# Altium schematic sheet Power_Supply_Unit.SchDoc
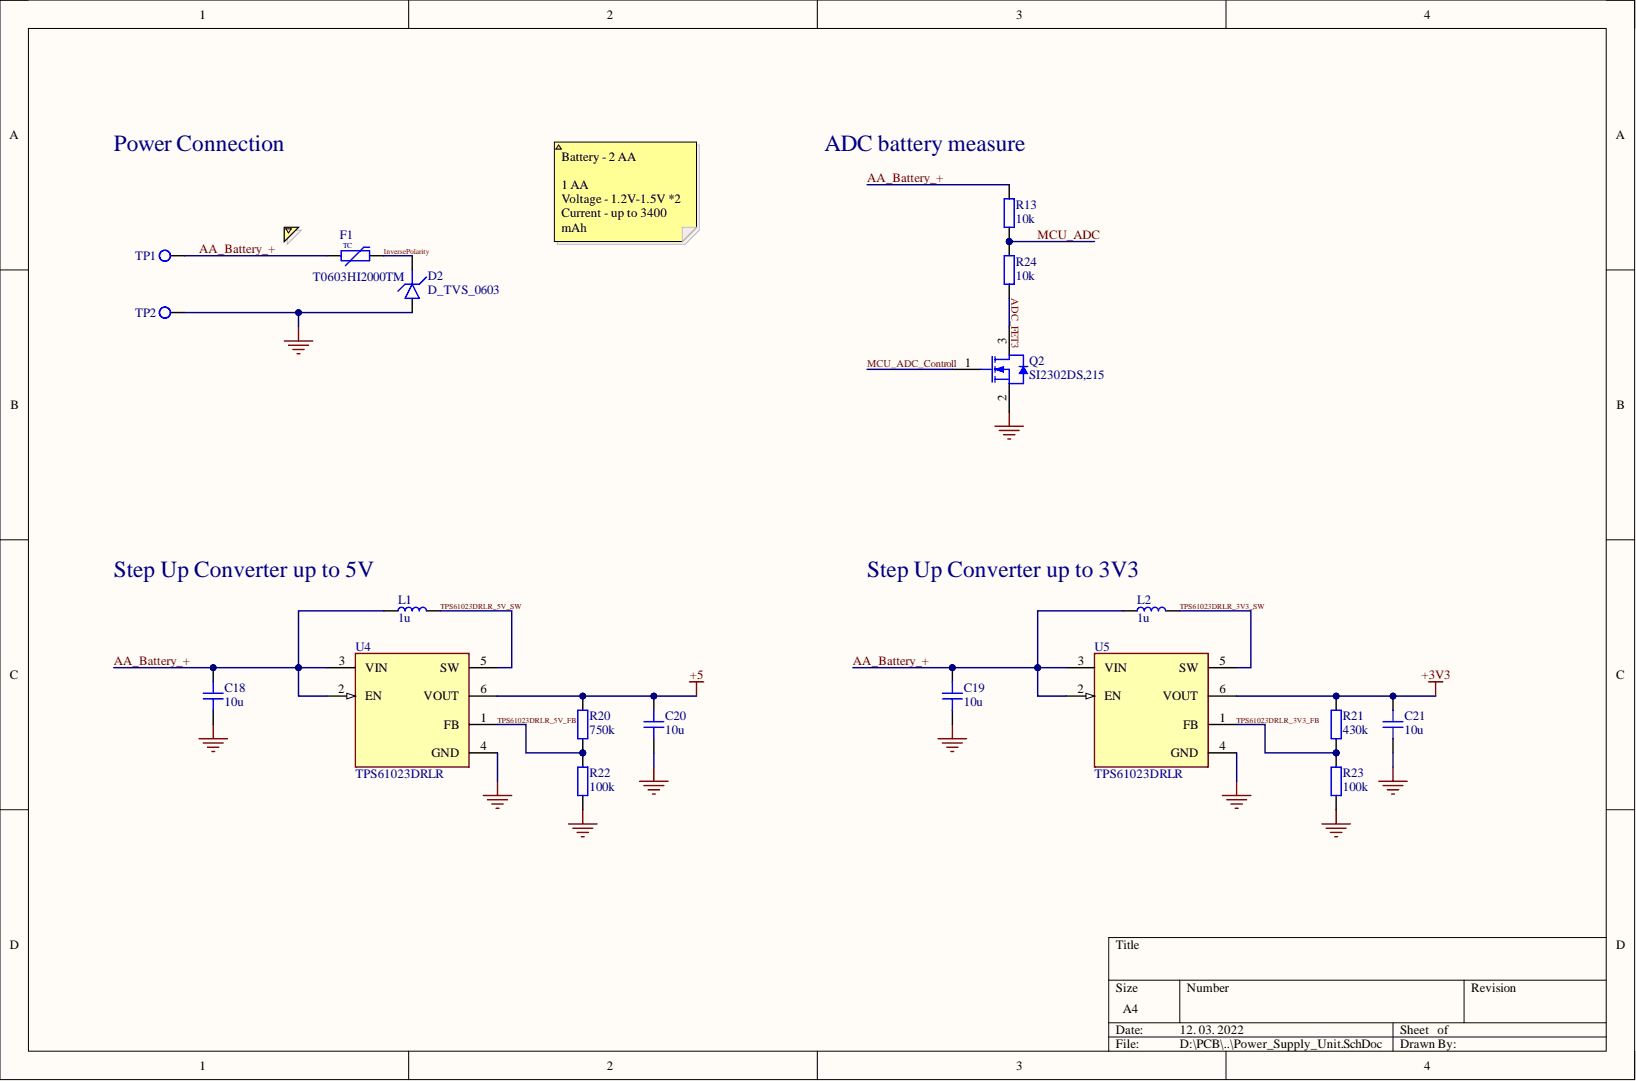
[diagram p0: Power_Supply_Unit.SchDoc]

## Parts
C18  10u  [CAP_0603]
C19  10u  [CAP_0603]
C20  10u  [CAP_0603]
C21  10u  [CAP_0603]
D2  D_TVS_0603  [DIOM2718X14N]
F1  T0603HI2000TM  [RESC1608X06N]
L1  1u  [IND_1210]
L2  1u  [IND_1210]
Q2  SI2302DS,215  [NXP-SOT23_N]
R13  10k  [RES_0603]
R20  750k  [RES_0603]
R21  430k  [RES_0603]
R22  100k  [RES_0603]
R23  100k  [RES_0603]
R24  10k  [RES_0603]
TP1  TestPoint  [SolderingPad]  (value not drawn)
TP2  TestPoint  [SolderingPad]  (value not drawn)
U4  TPS61023DRLR  [FP-DRL0006A-MFG]
U5  TPS61023DRLR  [FP-DRL0006A-MFG]

## Nets
+3V3: C21.2 R21.2 U5.6(VOUT)
+5: C20.2 R20.2 U4.6(VOUT)
GND: C18.1 C19.1 C20.1 C21.1 D2.2 Q2.2 R22.1 R23.1 TP2.1 U4.4(GND) U5.4(GND)
AA_Battery_+: C18.2 C19.2 F1.1 L1.1 L2.1 R13.2 TP1.1 U4.2(EN) U4.3(VIN) U5.2(EN) U5.3(VIN)
ADC_FET3: Q2.3 R24.1
InversePolarity: D2.1 F1.2
MCU_ADC: R13.1 R24.2
MCU_ADC_Controll: Q2.1
TPS61023DRLR_3V3_FB: R21.1 R23.2 U5.1(FB)
TPS61023DRLR_3V3_SW: L2.2 U5.5(SW)
TPS61023DRLR_5V_FB: R20.1 R22.2 U4.1(FB)
TPS61023DRLR_5V_SW: L1.2 U4.5(SW)

## Library data of the parts
C18-C21 = CAP_0603_10V: Capacitor SMD 0603 10V; Component Kind: Standard; Library Name: Schematic-Lib-PhilsLab.SchLib
D2 = D_TVS_0603: Diode TVS / ESD 0603; Component Kind: Standard; Library Name: OnlabComponents.SchLib
F1 = FUSE_0603: Fuse SMD 0603; Manufacturer: AEM; Component Kind: Standard; DataSheet: https://datasheet.lcsc.com/lcsc/1811151158_AEM-T0603HI2000TM_C311070.pdf; Manufacturer Part Number: T0603HI2000TM; Library Name: OnlabComponents.SchLib
L1 L2 = IND_1210: Inductor SMD 1210; Component Kind: Standard; Library Name: OnlabComponents.SchLib
Q2 = CMP-1258-00133-1: N-Channel Enhancement Mode Field-Effect Transistor, 20 V, 2.5 A, 3-Pin SOT23, Tape and Reel; Manufacturer: NXP Semiconductors; Channel Type: N; Ciss [typ] (pF): 230; Coss [typ] (pF): 125; ID [max] (A): 2.5; Packing: Tape & Reel; VDS [max] (V): 20; Code_JEDEC: TO-236AB; Component Kind: Standard; ComponentLink1Description: Manufacturer URL; ComponentLink1URL: http://www.nxp.com/; ComponentLink2Description: Datasheet; ComponentLink2URL: http://www.nxp.com/documents/data_sheet/SI2302DS.pdf; ComponentLink3Description: Package Specification; ComponentLink3URL: http://www.nxp.com/documents/outline_drawing/sot023_po.pdf; DatasheetVersion: Rev. 02; Library Name: Altium Content Vault; Mounting Technology: Surface Mount; PackageDescription: 3-Pin Small Outline Transistor Package, Body 2.9 x 1.3 mm, Pitch 0.95 mm; PackageReference: SOT23; PackageVersion: 06-03-16, 03/2006; PartNumber: SI2302DS,215
R13 R20-R24 = RES_0603: Resistor SMD 0603; Component Kind: Standard; Library Name: Schematic-Lib-PhilsLab.SchLib
U4 U5 = CMP-04918-000638-1: FINISH GOODS FOR TPS61023; Component Kind: Standard; Library Name: Altium Content Vault
TP1 TP2 = TestPoint: Component Kind: Standard; Library Name: OnlabComponents.SchLib
